# Altium schematic sheet Top.SchDoc
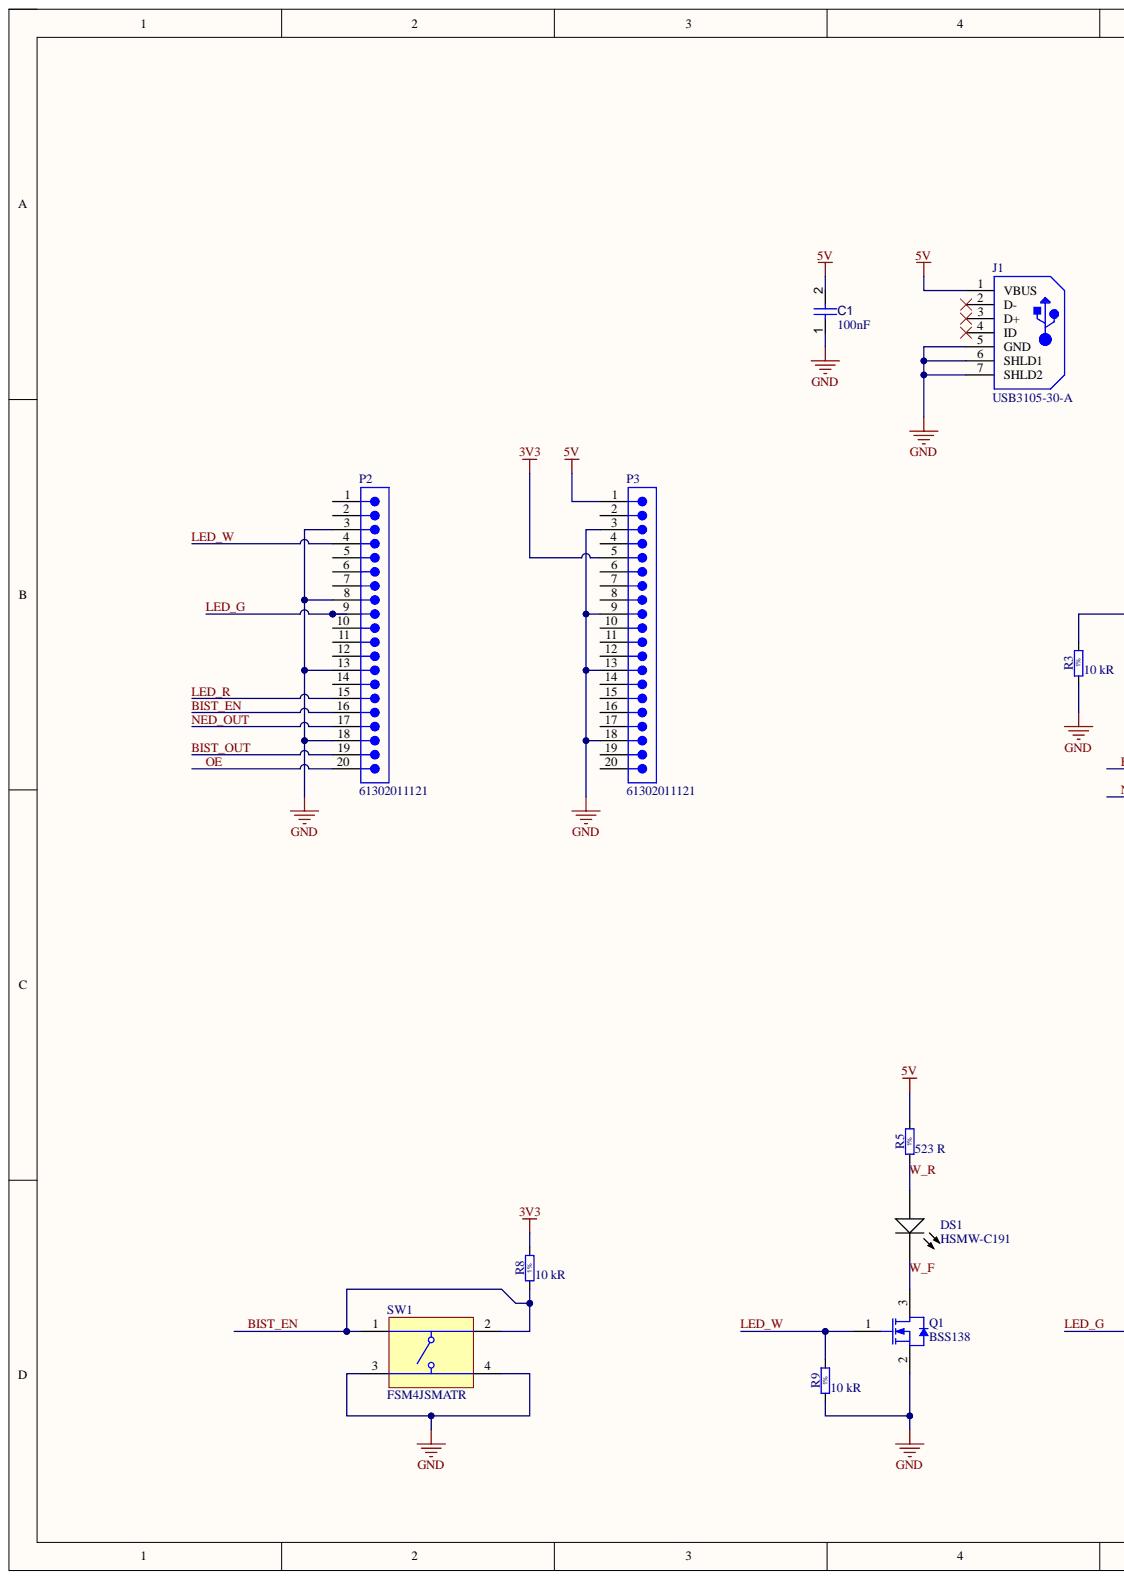
[diagram p0: Top.SchDoc, left]
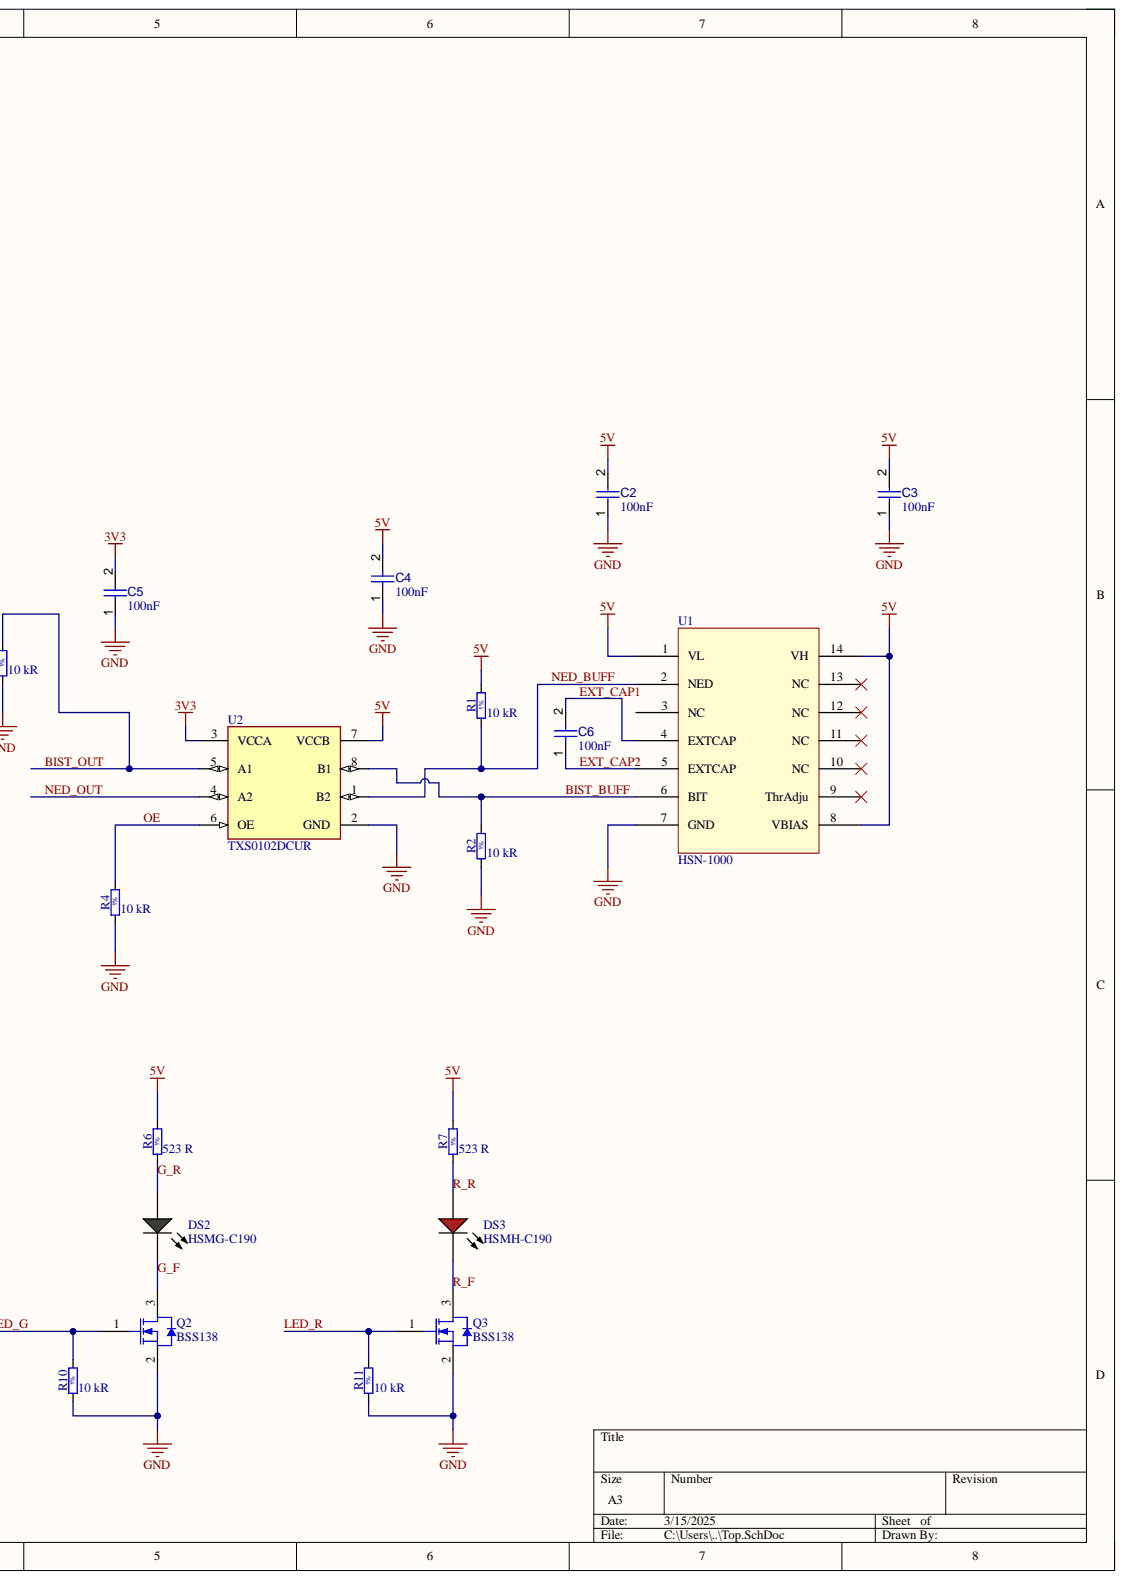
[diagram p1: Top.SchDoc, right]

## Parts
C1  value 100nF  [CAPC1608X90X40NL10T20]  (hidden comment: Capacitor 100 nF +/-10% 50 V 0603)
C2  value 100nF  [CAPC1608X90X40NL10T20]  (hidden comment: Capacitor 100 nF +/-10% 50 V 0603)
C3  value 100nF  [CAPC1608X90X40NL10T20]  (hidden comment: Capacitor 100 nF +/-10% 50 V 0603)
C4  value 100nF  [CAPC1608X90X40NL10T20]  (hidden comment: Capacitor 100 nF +/-10% 50 V 0603)
C5  value 100nF  [CAPC1608X90X40NL10T20]  (hidden comment: Capacitor 100 nF +/-10% 50 V 0603)
C6  value 100nF  [CAPC1608X90X40NL10T20]  (hidden comment: Capacitor 100 nF +/-10% 50 V 0603)
DS1  HSMW-C191  [AVAG-HSMW-C191_V]
DS2  HSMG-C190  [AVAG-HSMX-C190_V]
DS3  HSMH-C190  [AVAG-HSMX-C190_V]
J1  USB3105-30-A  [GCT-USB3105-30-A_V]
P2  61302011121  [61302011121]
P3  61302011121  [61302011121]
Q1  BSS138  [FAIR-SOT23-3_V]
Q2  BSS138  [FAIR-SOT23-3_V]
Q3  BSS138  [FAIR-SOT23-3_V]
R1  value 10 kR  Tolerance: 1%  [RESC1608X55X25NL10T15]  (hidden comment: RC0603FR-0710KL)
R2  value 10 kR  Tolerance: 1%  [RESC1608X55X25NL10T15]  (hidden comment: RC0603FR-0710KL)
R3  value 10 kR  Tolerance: 1%  [RESC1608X55X25NL10T15]  (hidden comment: RC0603FR-0710KL)
R4  value 10 kR  Tolerance: 1%  [RESC1608X55X25NL10T15]  (hidden comment: RC0603FR-0710KL)
R5  value 523 R  Tolerance: 1%  [RESC1608X55X25NL10T15]  (hidden comment: RC0603FR-07523RL)
R6  value 523 R  Tolerance: 1%  [RESC1608X55X25NL10T15]  (hidden comment: RC0603FR-07523RL)
R7  value 523 R  Tolerance: 1%  [RESC1608X55X25NL10T15]  (hidden comment: RC0603FR-07523RL)
R8  value 10 kR  Tolerance: 1%  [RESC1608X55X25NL10T15]  (hidden comment: RC0603FR-0710KL)
R9  value 10 kR  Tolerance: 1%  [RESC1608X55X25NL10T15]  (hidden comment: RC0603FR-0710KL)
R10  value 10 kR  Tolerance: 1%  [RESC1608X55X25NL10T15]  (hidden comment: RC0603FR-0710KL)
R11  value 10 kR  Tolerance: 1%  [RESC1608X55X25NL10T15]  (hidden comment: RC0603FR-0710KL)
SW1  FSM4JSMATR  [TECO-FSM4JSMATR_V]
U1  HSN-1000  [HSN-1000]
U2  TXS0102DCUR  [FP-DCU0008A-MFG]

## Nets
3V3: C5.2 P3.5 R8.2 U2.3(VCCA)
5V: C1.2 C2.2 C3.2 C4.2 J1.1(VBUS) P3.1 R1.2 R5.2 R6.2 R7.2 U1.1(VL) U1.8(VBIAS) U1.14(VH) U2.7(VCCB)
GND: C1.1 C2.1 C3.1 C4.1 C5.1 J1.5(GND) J1.6(SHLD1) J1.7(SHLD2) P2.3 P2.8 P2.13 P2.18 P3.3 P3.9 P3.13 P3.18 Q1.2 Q2.2 Q3.2 R2.1 R3.1 R4.1 R9.1 R10.1 R11.1 SW1.3 SW1.4 U1.7(GND) U2.2(GND)
BIST_BUFF: R2.2 U1.6(BIT) U2.8(B1)
BIST_EN: P2.16 R8.1 SW1.1 SW1.2
BIST_OUT: P2.19 R3.2 U2.5(A1)
EXT_CAP1: C6.2 U1.4(EXTCAP)
EXT_CAP2: C6.1 U1.5(EXTCAP)
G_F: DS2.2 Q2.3
G_R: DS2.1 R6.1
LED_G: P2.9 Q2.1 R10.2
LED_R: P2.15 Q3.1 R11.2
LED_W: P2.4 Q1.1 R9.2
NED_BUFF: R1.1 U1.2(NED) U2.1(B2)
NED_OUT: P2.17 U2.4(A2)
OE: P2.20 R4.2 U2.6(OE)
R_F: DS3.2 Q3.3
R_R: DS3.1 R7.1
W_F: DS1.2 Q1.3
W_R: DS1.1 R5.1

Not connected: J1 2(D-) 3(D+) 4(ID); P2 1 2 5 6 7 10 11 12 14; P3 2 4 6 7 8 10 11 12 14 15 16 17 19 20; U1 3(NC) 9(ThrAdju) 10(NC) 11(NC) 12(NC) 13(NC)

## Library data of the parts
C1-C6 = CMP-001-00017-6: Chip Capacitor, 100 nF, +/- 10%, 50 V, 0603 (1608 Metric); Capacitor Type: Ceramic; Voltage Rating: 50V; Case/Package: 0603; Component Kind: Standard; Max Operating Temperature: 125°C; Min Operating Temperature: -55°C; Mounting Technology: SM; Pins: 2; RoHS Compliant: True; Tolerance: 10%; Library Name: Salience Labs Ltd
DS1 = CMP-007-00016-2: LED Uni-Color White, 78 mW, 20 mA, -40 to 85 degC, 2-Pin SMD, RoHS, Tape and Reel; Manufacturer: Avago; Forward Current: 20mA; Forward Voltage: 3.6V; Lens Style: Diffused; Luminous Intensity: 200mcd; Power: 78mW; Viewing Angle: 140degrees; Case/Package: 0603; Colour: White; Component Kind: Standard; Datasheet URL: http://docs.avagotech.com/docs/AV02-0186EN; Datasheet Version: May-10; Illumination Colour: White; Manufacturer URL: http://www.avagotech.com/; Max Operating Temperature: 85°C; Min Operating Temperature: -40°C; Mounting Technology: SM; Nominal Vf: 3.6V; Package Description: 2-Pin Surface Mount Device, Body 1.6 x 0.8 mm; Package Quantity: 4000; Package Reference: HSMW-C191; Packaging: TapeandReel; Pins: 2; RoHS Compliant: True; Library Name: Salience Labs Ltd
DS2 = CMP-007-00013-2: LED Uni-Color Green, 52 mW, 20 mA, -40 to 85 degC, 2-Pin SMD, RoHS, Tape and Reel; Manufacturer: Avago; Forward Current: 20mA; Forward Voltage: 2.2V; Lens Style: Diffused; Luminous Intensity: 15mcd; Power: 52mW; Viewing Angle: 170degrees; Case/Package: 0603; Colour: Green; Component Kind: Standard; Datasheet URL: http://docs.avagotech.com/docs/AV02-0551EN; Datasheet Version: Mar-12; Illumination Colour: Green; Manufacturer URL: http://www.avagotech.com/; Max Operating Temperature: 85°C; Min Operating Temperature: -40°C; Mounting Technology: SM; Nominal Vf: 2.2V; Package Description: 2-Pin Surface Mount Device, Body 1.6 x 0.8 mm, Height 0.9 mm; Package Quantity: 4000; Package Reference: HSMX-C190; Packaging: TapeandReel; Pins: 2; RoHS Compliant: True; Library Name: Salience Labs Ltd
DS3 = CMP-007-00014-2: LED Uni-Color Red, 65 mW, 20 mA, -40 to 85 degC, 2-Pin SMD, RoHS, Tape and Reel; Manufacturer: Avago; Forward Current: 20mA; Forward Voltage: 1.8V; Lens Style: Diffused; Luminous Intensity: 17mcd; Power: 78mW; Viewing Angle: 170degrees; Case/Package: 0603; Colour: Red; Component Kind: Standard; Datasheet URL: http://docs.avagotech.com/docs/AV02-0551EN; Datasheet Version: Mar-12; Illumination Colour: Red; Manufacturer URL: http://www.avagotech.com/; Max Operating Temperature: 85°C; Min Operating Temperature: -40°C; Mounting Technology: SM; Nominal Vf: 1.8V; Package Description: 2-Pin Surface Mount Device, Body 1.6 x 0.8 mm, Height 0.9 mm; Package Quantity: 4000; Package Reference: HSMX-C190; Packaging: TapeandReel; Pins: 2; RoHS Compliant: True; Library Name: Salience Labs Ltd
J1 = CMP-2000-07366-1: USB 2.0 A/B Receptacle, 30 V, -55 to 85 degC, 5-Pin THD, RoHS, Tape and Reel; Manufacturer: Global Connector Technology; Connector Mounting: ThroughHoleMount; No. of Positions: 5Ways; USB Connector Type: MicroUSBTypeAB; USB Standard: USB2.0; Component Kind: Standard; Contact Material: CopperAlloy; Contact Plating: GoldPlatedContacts; Datasheet URL: http://gct.co/usb-connectors/pdf/USB3105.pdf; Datasheet Version: Rev. F, 05/2015; Gender: Receptacle; Library Name: Altium Content Vault; Manufacturer URL: http://gct.co/; Mounting Technology: ThroughHole; Orientation: Vertical; Package Description: 5-Pin Through Hole Device, Body 7.96 x 2.9 mm, Pitch 0.65 mm; Package Reference: USB3105-30-A; Product Range: -
P2 P3 = CMP-1502-01102-3: NexarPartId: 32855554; Connector Type: Male Pin; Contact Resistance: 20mΩ; Current Rating: 3A; Gender: Male; Number of Contacts: 20; Operating Supply Voltage: 250V; Pitch: 2.54mm; Color: Black; Component Kind: Standard; Contact Material: Copper; Contact Mating Length: 5.9944mm; Contact Plating: Gold; Lead Pitch: 2.54mm; Library Name: Altium Content Vault; Mount: Through Hole; Number of Pins: 20; Number of Positions: 20; Number of Rows: 1; Orientation: Vertical; Packaging: Bulk; REACH SVHC: Unknown; RoHS: Compliant; Termination: Solder
Q1-Q3 = CMP-013-00021-4: N-Channel Logic Level Enhancement Mode Field Effect Transistor, 220 mA, 50 V, -55 to 150 degC, 3-Pin SOT-23, RoHS, Tape and Reel; Manufacturer: Fairchild; Elements: 1; Input Capacitance: 27pF; Nominal Dual Supply Voltage: 50V; Nominal Vgs: 1.3V; Package Version: Rev. 12, 03/2016; Power: 360mW; Rds On Max: 3.5Ohm; Resistance: 0.7Ohm; Typical Rise Time: 9ns; Case/Package: TO-236-3; Component Kind: Standard; Datasheet URL: https://www.fairchildsemi.com/datasheets/BS/BSS138.pdf; Datasheet Version: Rev. C, 10/2005; Max Operating Temperature: 150°C; Min Operating Temperature: -55°C; Mounting Technology: SM; Package Description: 3-Pin Small Outline Transistor, Body 2.92 x 1.325 mm, Pitch 0.95 mm; Package Quantity: 1; Package Reference: SOT23-3; Packaging: TapeandReel; Pins: 3; RoHS Compliant: True; Library Name: Salience Labs Ltd
R1-R4 R8 = CMP-009-02027-2: RES 10K OHM 1% 1/10W 0603; Power Rating: 0.1W, 1/10W; Temperature Coefficient: ±100ppm/°C; Case Code (Imperial): 0603; Component Kind: Standard; Features: Moisture Resistant; Operating Temperature: -55°C ~ 155°C; Resistor Type: Thick Film; RoHS Compliant: Yes; Series: RC_L; Supplier Part #: 311-10.0KHRTR-ND; Library Name: Salience Labs Ltd
R5-R7 = CMP-009-01881-2: RES 523 OHM 1% 1/10W 0603; Power Rating: 0.1W, 1/10W; Temperature Coefficient: ±100ppm/°C; Case Code (Imperial): 0603; Component Kind: Standard; Features: Moisture Resistant; Operating Temperature: -55°C ~ 155°C; Resistor Type: Thick Film; RoHS Compliant: Yes; Series: RC_L; Supplier Part #: 311-523HRTR-ND; Library Name: Salience Labs Ltd
R9-R11 = CMP-009-02027-2: RES 10K OHM 1% 1/10W 0603; Power Rating: 0.1W, 1/10W; Temperature Coefficient: ±100ppm/°C; Value: 10 kR; Case Code (Imperial): 0603; Component Kind: Standard; Features: Moisture Resistant; Operating Temperature: -55°C ~ 155°C; Resistor Type: Thick Film; RoHS Compliant: Yes; Series: RC_L; Supplier Part #: 311-10.0KHRTR-ND; Library Name: Salience Labs Ltd
SW1 = CMP-011-00014-1: Switch; Pushbutton; Tactile; SPST; 50mA; SMT; Off(On); 6x6mm; Actuator Color: Black; Actuator Length: 1.4mm; Actuator Material: Polyphenylene; Actuator Type: Standard; Connector Type: SMD/SMT; Illumination Type: Non-Illuminated,Illuminated; Max Voltage Rating (DC): 12V; Mechanical Life: 100000Cycles; Number of Positions: 2; Operating Force: 160gf; Switch Function: Off-Mom; Switch Travel: 0.25mm; Value: 0F; Case/Package: SMD/SMT; Component Kind: Standard; Contact Current Rating: 50mA; Contact Material: Copper Alloy,Copper,Silver; Contact Plating: Silver; Current Rating: 50mA; Depth: 10mm; Lead Free: Lead Free; Length: 6mm; Max Current Rating: 50mA; Max Operating Temperature: 85°C; Min Operating Temperature: -35°C; Mounting Technology: Surface Mount; Orientation: Straight; Package Quantity: 1; Packaging: Tape and Reel; Radiation Hardening: No; Reach SVHC Compliant: Unknown; RoHS Compliant: Yes; Series: FSMJSMA; Termination: Gull Wing; Throw Configuration: SPST; Type: Switch; Voltage Rating (DC): 12V; Library Name: Salience Labs Ltd
U2 = CMP-0859-00001-2: IC TRNSLTR BIDIRECTIONAL US8; Manufacturer: Texas Instruments; Data Rate: 24Mbits/s; Logic Function Desc: Bidirectional Voltage-Level Translator; Max Junction Temp: +150°C; Max Supply Voltage: 5.5V; Min Supply Voltage: 1.65V; Nominal Supply Current: 1-14.4uA; Number Of Circuits: 1; Number Of Inputs: 2; Standoff Height: 0mm; V A Max: 3.6V; V B Min: 2.3V; Ambient Temperature Range High: +85°C; Ambient Temperature Range Low: -40°C; Automotive: No; Category: IC; Component Kind: Standard; ComponentLink2Description: Datasheet; ComponentLink2URL: http://www.ti.com/lit/ds/symlink/txs0102.pdf; Device Class L1: Integrated Circuits (ICs); Device Class L2: Logic ICs; Device Class L3: Voltage Level Shifters; Digikey Description: IC TRNSLTR BIDIRECTIONAL US8; Height: 0.9mm; Lead Free: Yes; Library Name: Altium Content Vault; Manufacturer Part Number: TXS0102DCUR; Mouser Description: Translation - Voltage Levels 2-Bit Bi-Directional Voltage-Level-Trans; Number Of Outputs: 2; Octopart: https://octopart.com/txs0102dcur-texas+instruments-5414308; Package: DCU0008A; RoHS: TRUE; Temperature: -40°C to +85°C; Temperature Range High: +85°C; Temperature Range Low: -40°C; V A Min: 1.65V; V B Max: 5.5V
U1 = HSN-1000: Component Kind: Standard; Library Name: nuc.SchLib
